# Altium schematic sheet Analog_Buffer.SchDoc
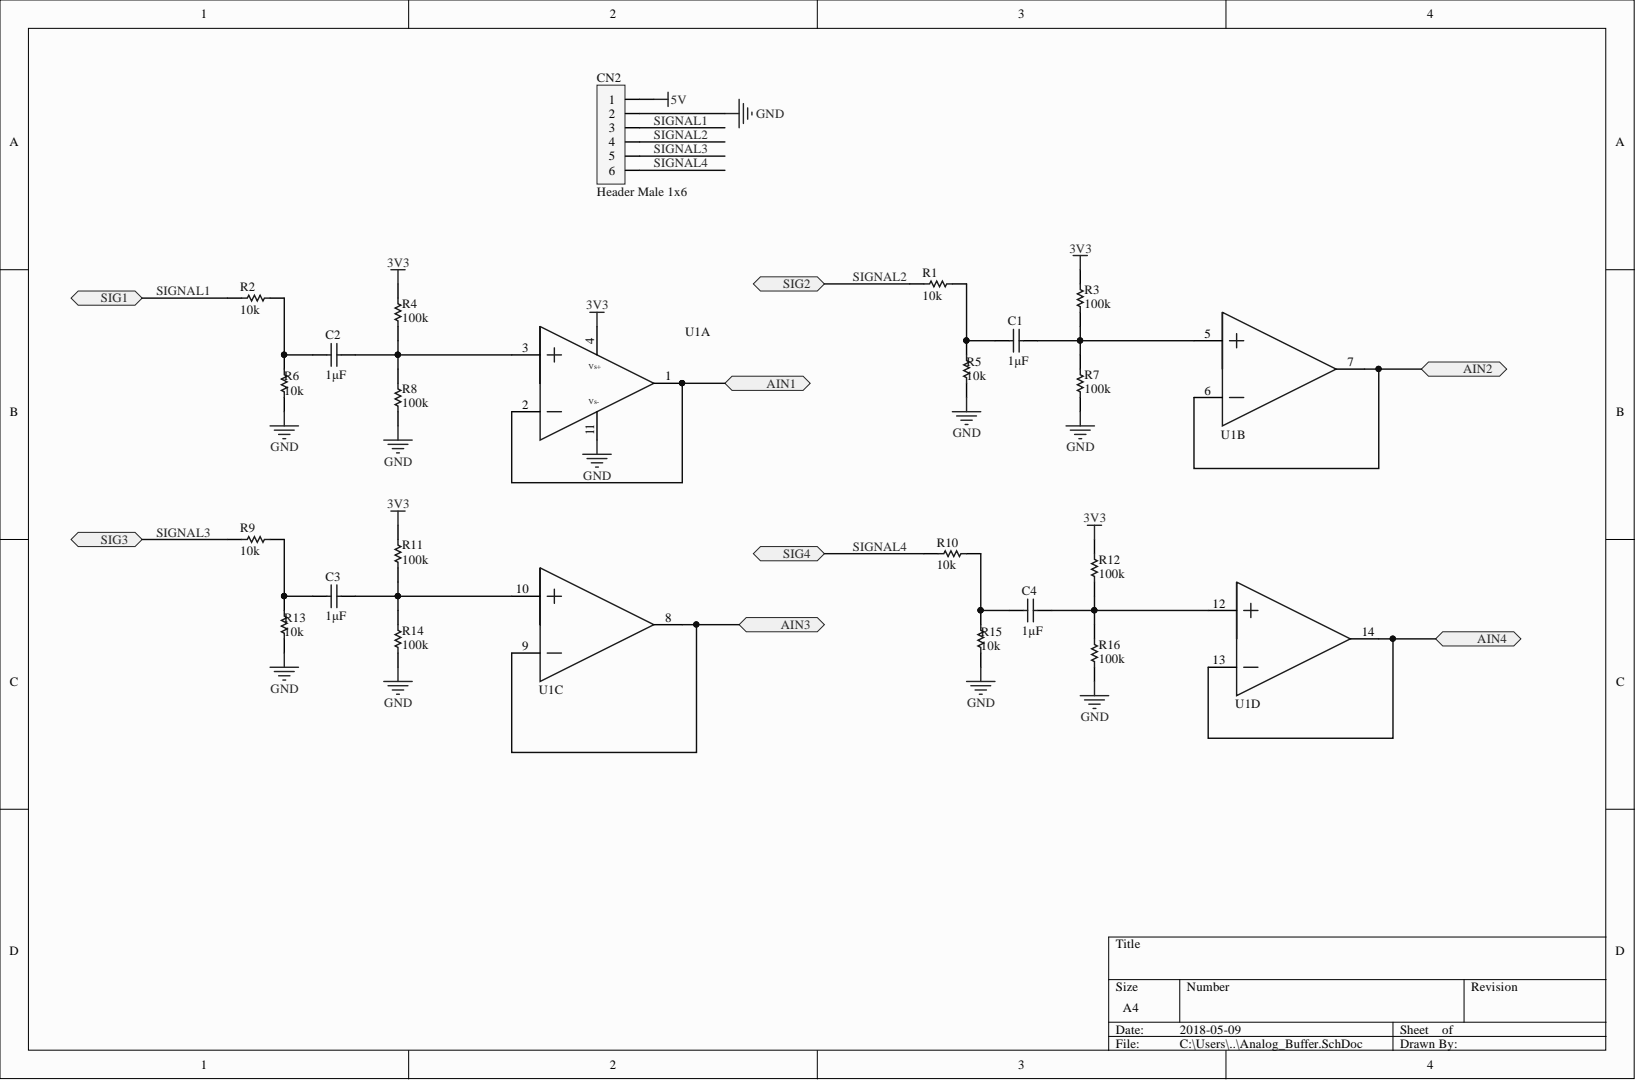
[diagram p0: Analog_Buffer.SchDoc]

## Parts
C1  1μF  [CAPC1608N(0603")]
C2  1μF  [CAPC1608N(0603")]
C3  1μF  [CAPC1608N(0603")]
C4  1μF  [CAPC1608N(0603")]
CN2  Header Male 1x6  [Header, Male, 1X6]
R1  10k  [RESC1608N(0603")]
R2  10k  [RESC1608N(0603")]
R3  100k  [RESC1608N(0603")]
R4  100k  [RESC1608N(0603")]
R5  10k  [RESC1608N(0603")]
R6  10k  [RESC1608N(0603")]
R7  100k  [RESC1608N(0603")]
R8  100k  [RESC1608N(0603")]
R9  10k  [RESC1608N(0603")]
R10  10k  [RESC1608N(0603")]
R11  100k  [RESC1608N(0603")]
R12  100k  [RESC1608N(0603")]
R13  10k  [RESC1608N(0603")]
R14  100k  [RESC1608N(0603")]
R15  10k  [RESC1608N(0603")]
R16  100k  [RESC1608N(0603")]
U1  Quad Op Amp TSV324  [SOP-14]  (value not drawn)  (parts A,B,C,D)

## Ports
AIN1, AIN2, AIN3, AIN4, SIG1, SIG2, SIG3, SIG4

## Nets
3V3: R3.2 R4.2 R11.2 R12.2 U1.4
5V: CN2.1
GND: CN2.2 R5.1 R6.1 R7.1 R8.1 R13.1 R14.1 R15.1 R16.1 U1.11
AIN1: U1.1 U1.2 ; port AIN1
AIN2: U1.6 U1.7 ; port AIN2
AIN3: U1.8 U1.9 ; port AIN3
AIN4: U1.13 U1.14 ; port AIN4
SIGNAL1: CN2.3 R2.1 ; port SIG1
SIGNAL2: CN2.4 R1.1 ; port SIG2
SIGNAL3: CN2.5 R9.1 ; port SIG3
SIGNAL4: CN2.6 R10.1 ; port SIG4
NetC1_1: C1.1 R1.2 R5.2
NetC1_2: C1.2 R3.1 R7.2 U1.5
NetC2_1: C2.1 R2.2 R6.2
NetC2_2: C2.2 R4.1 R8.2 U1.3
NetC3_1: C3.1 R9.2 R13.2
NetC3_2: C3.2 R11.1 R14.2 U1.10
NetC4_1: C4.1 R10.2 R15.2
NetC4_2: C4.2 R12.1 R16.2 U1.12

## Library data of the parts
C1-C4 = 1uF 25V 0603 SMD, Ceramic: Capacitor, Ceramic, 25V, +/- 10%, 0603 SMD, X5R; MFG: Murata-Electronics-North-America; MFG#: GRM188R61E105KA12D; Component Kind: Standard; PackageDescription: Chip Capacitor; Published: 16-06-27; Supplier 1: Digi-Key; Supplier Part Number 1: 490-3897-1-ND; Value: 1μF; Created By: Andy Bates; Library Name: AUVic_Integrated_Library_Capacitors.IntLib; Publisher: AUVic
CN2 = Header, Male, 1x6: 1x6, Pads Pitch: 0.1" (2.54mm), Through hole; Made Bates: Andy Bates; Component Kind: Standard; Supplier 1: Digikey; Supplier 1 Part Number: 952-2270-ND; Library Name: AUVic_Integrated_Library_Connectors.IntLib
R1 R2 R5 R6 R9 R10 R13 R15 = 10kR, 1%, 1/10W, 0603 SMD: Made By: Ashwin Sira; Owned By: AUVic; Component Kind: Standard; Created On: 14-05-31; Description: 10kR, 1%, 1/10W, 0603 SMD; Last Edited: 14-05-31; Library Name: AUVic_Integrated_Library_Resistors.IntLib; Supplier 1: Digi-Key; Supplier Part Number 1: 311-10.0KHRCT-ND; Value: 10k
R3 R4 R7 R8 R11 R12 R14 R16 = 100kR, 1%, 1/10W, 0603 SMD: Made By: Andy Bates; Owned By: AUVic; Component Kind: Standard; Created On: 14-06-30; Description: 100kR, 1%, 1/10W, 0603 SMD; Last Edited: 14-06-30; Library Name: AUVic_Integrated_Library_Resistors.IntLib; Supplier 1: Digi-Key; Supplier Part Number 1: 311-100KGRCT-ND; Value: 100k
U1 = Quad Op Amp TSV324: TSV324; Component Kind: Standard; Creation Date: 10/14/2016; LatestRevisionNote: Re-released for DXP Platform.; Supplier: Digikey; Supplier Part Number: 497-8198-2-ND; Created By: Jordan; Library Name: AUVic_Integrated_Library_Op_amps.IntLib; Publisher: Altium Limited
